# Altium schematic sheet TopLevel.SchDoc(TopLevel)
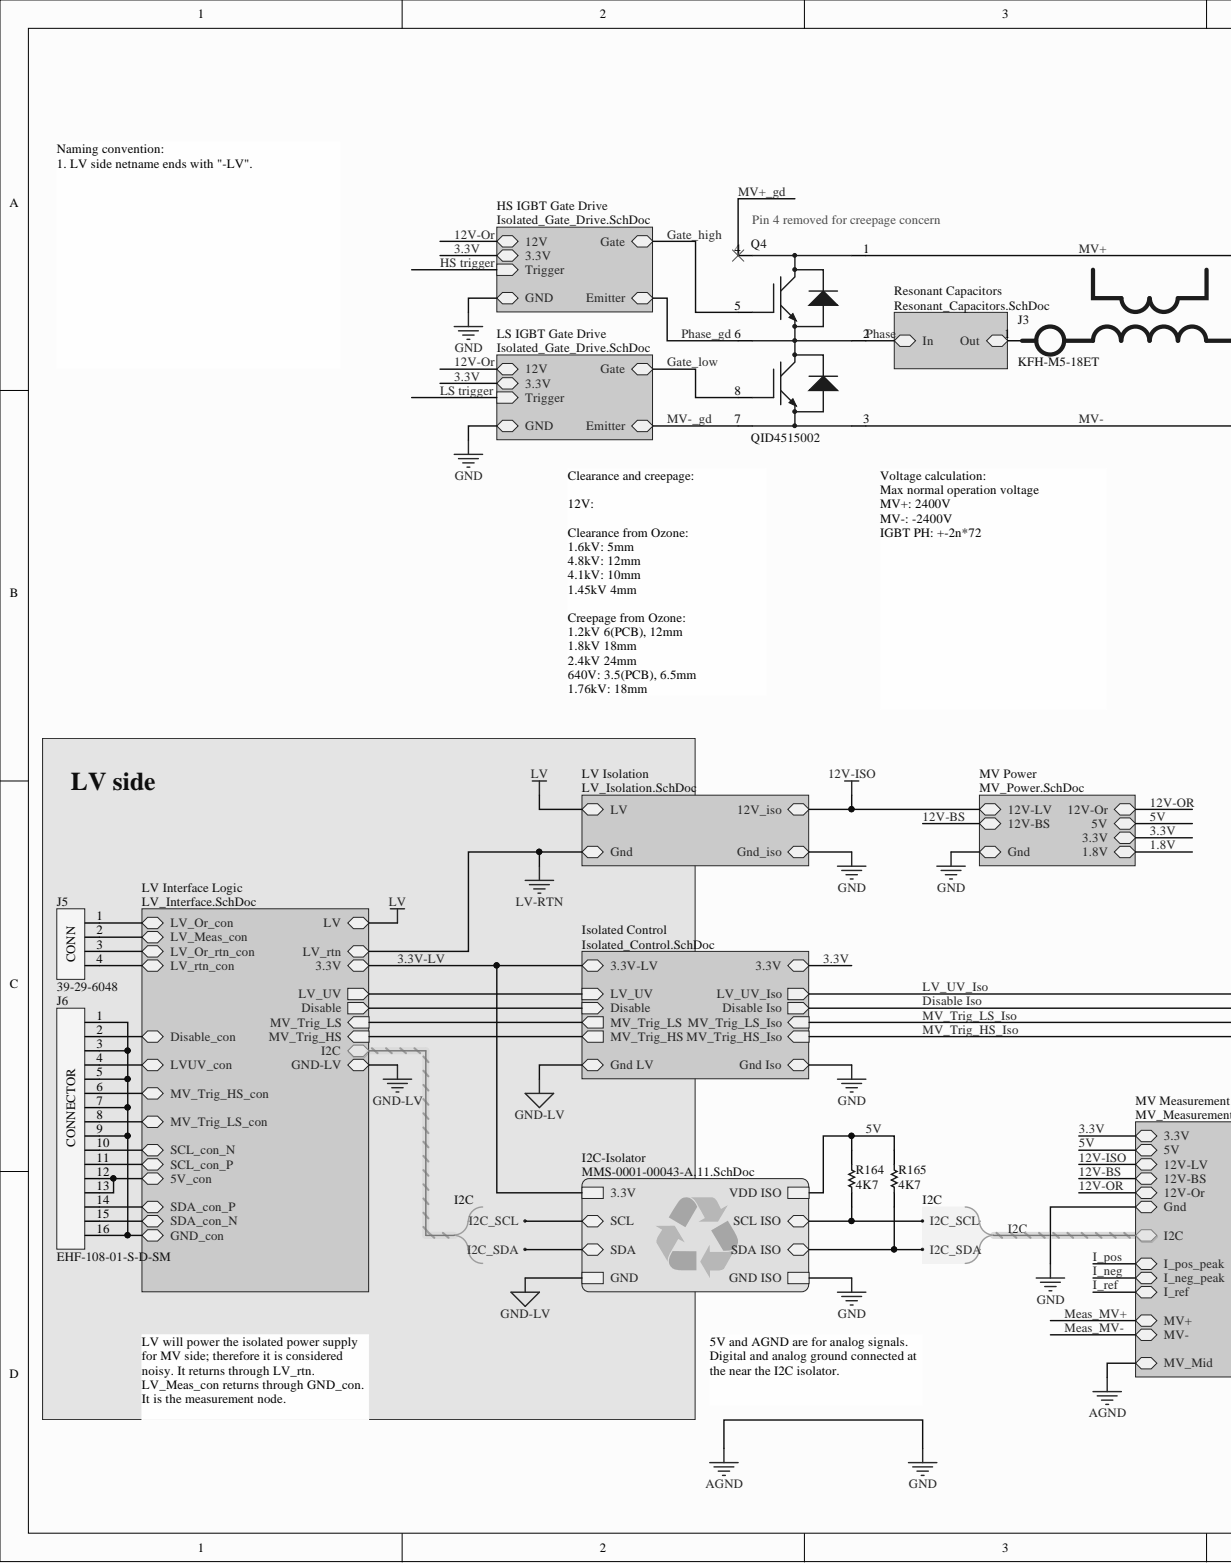
[diagram p0: TopLevel.SchDoc, left]
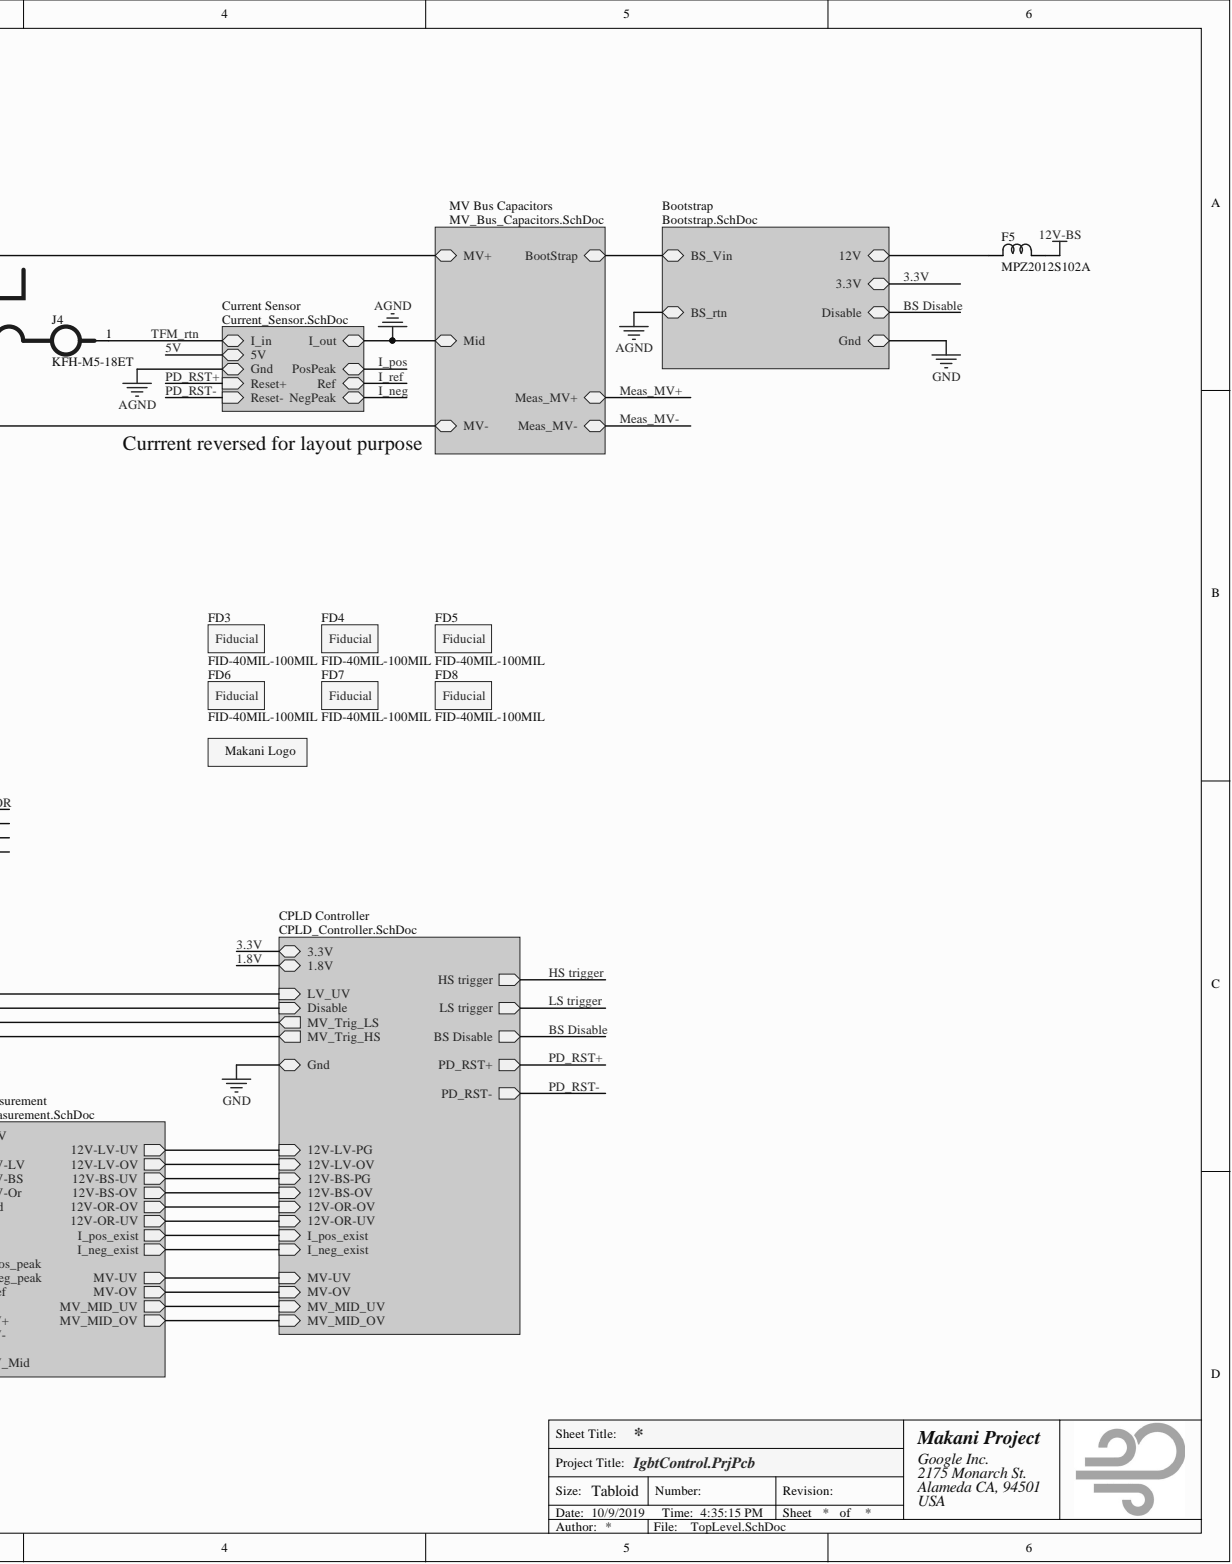
[diagram p1: TopLevel.SchDoc, right]

## Parts
F5  MPZ2012S102A  [FERRITE-0805-1P05MM]
FD3  FID-40MIL-100MIL  [FID-40MIL-100MIL]  (no BOM)
FD4  FID-40MIL-100MIL  [FID-40MIL-100MIL]  (no BOM)
FD5  FID-40MIL-100MIL  [FID-40MIL-100MIL]  (no BOM)
FD6  FID-40MIL-100MIL  [FID-40MIL-100MIL]  (no BOM)
FD7  FID-40MIL-100MIL  [FID-40MIL-100MIL]  (no BOM)
FD8  FID-40MIL-100MIL  [FID-40MIL-100MIL]  (no BOM)
J3  KFH-M5-18ET  [PENN-KFH-M5-18ET]
J4  KFH-M5-18ET  [PENN-KFH-M5-18ET]
J5  39-29-6048  [MOLX-39-29-6048 - duplicate]
J6  EHF-108-01-S-D-SM  [SAM-EHF-108-01-X-D-SM]
Logo1  Makani Logo  [MAKANI-LOGO-200MIL]  (no BOM)
Q4  QID4515002  [PWRX-QID-IGBT-HB]
R164  value 4K7  [RESC0603(1608)_N]  (hidden comment: 4K7 1% 0603(1608))
R165  value 4K7  [RESC0603(1608)_N]  (hidden comment: 4K7 1% 0603(1608))

## Nets
5V: R164.2 R165.2
12V-BS: F5.2
Gate_high: Q4.5
Gate_low: Q4.8
I2C.I2C_SCL: R164.1
I2C.I2C_SDA: R165.1
MV+: Q4.1
MV+_gd: Q4.4
MV-: Q4.3
MV-_gd: Q4.7
Phase: Q4.2
Phase_gd: Q4.6
TFM_rtn: J4.1
NetF5_1: F5.1
NetJ3_1: J3.1
NetJ5_1: J5.1
NetJ5_2: J5.2
NetJ5_3: J5.3
NetJ5_4: J5.4
NetJ6_1: J6.1 J6.3 J6.5 J6.7 J6.9 J6.16
NetJ6_2: J6.2
NetJ6_4: J6.4
NetJ6_6: J6.6
NetJ6_8: J6.8
NetJ6_10: J6.10
NetJ6_11: J6.11
NetJ6_12: J6.12 J6.13
NetJ6_14: J6.14
NetJ6_15: J6.15
1.8V:
3.3V:
3.3V-LV:
12V-ISO:
12V-Or:
AGND:
BS Disable:
Disable Iso:
GND-LV:
HS trigger:
I_neg:
I_pos:
I_ref:
LS trigger:
LV:
LV-RTN:
LV_UV_Iso:
Meas_MV+:
Meas_MV-:
MV_Trig_HS_Iso:
MV_Trig_LS_Iso:
N00432:
N00433:
N00434:
N00435:
N00436:
N00437:
N00438:
N00439:
N00440:
N00441:
N00442:
N00443:
N00444:
N00445:
N00446:
N00447:
N00448:
N00449:
N00450:
PD_RST+:
PD_RST-:

## Library data of the parts
F5 = CMP-0010-00044-3: 1000 Ohm, 1.5A, 0805 Chip Ferrite; Rated Current: 1.5; Mfg P/N: MPZ2012S102AT000; Mfg: TDK; Impedance @ 100MHz: 1000; GPN: 185-00037-00; DCR: 150; Temperature Range: -40 to 125; Supplier 1 P/N: 445-5223-1-ND; Supplier 1: DigiKey; HelpURL: http://www.tdk.co.jp/tefe02/e9413_mpz.pdf; Component Kind: Standard; Library Name: Makani Vault(migrated)
FD3-FD8 = CMP-0015-00000-1: Fiducial with 40mil copper and 100mil mask; Library Name: Makani Vault(migrated)
J3 J4 = CMP-0198-00008-4: TH 18mm studs, M5 thread; RevisionId: 1; Mfg: Penn Engineering; Folder: Components\Vendor\PENN ENG\PemNuts\; ContentType: Unified Component; AccessRights: 2147483647; Thread: M5; Supplier 1 P/N: PEMKFH-M5-18; Supplier 1: D.B. Roberts; Stud height: 18 mm; ReleaseDate: 22/03/2018 07:11:37 PM; Mfg P/N: KFH-M5-18ET; Material: Electroplated Tin; LifeCycleStateGUID: C94A9D87-DE22-4BE7-AEE4-C062168FB4CE; LifeCycle: Released; ItemHRID: CMP-0198-00008; ItemGUID: 77C44E83-7D12-4A08-AA89-24D5318BCFB5; HelpURL: http://www.pemnet.com/fastening_products/pdf/kdata.pdf; FolderGUID: 93817CE6-3017-44FA-8E59-A4AFD8205243; ContentTypeGUID: CB3C11C4-E317-11DF-B822-12313F0024A2; ComponentType: Connector; Component Kind: Standard; AncestorItemRevisionGUID: 9C205294-C155-4775-9783-1015C7892AE1; Library Name: Makani Vault(migrated)
J5 = CMP-0180-00001-5: Mini-Fit Jr, Vertical, Dual row, 4 pin; Rows: 2; Pitch: 4.2; Mfg: MOLEX; GPN: 210-00759-00; Contact Thickness: 0.38; Circuits: 4; Termination: Through Hole; Supplier 1 P/N: WM23821-ND; Supplier 1: DigiKey; Orientation: Vertical; Mfg P/N: 39-29-6048; HelpURL: http://www.molex.com/pdm_docs/sd/039281103_sd.pdf; Contact Finish: Gold; Component Kind: Standard; Library Name: Makani Vault(migrated)
J6 = CMP-0145-00031-1: 50mil 16pos Vertical SMD IDC Ejector Header; Positions: 16; Plating: 0.76um Gold; Mfg: Samtec Inc.; Locking: Yes; Supplier 1 P/N: EHF-108-01-S-D-SM; Supplier 1: Samtec Inc.; Pitch: 50mil; Orientation: Vertical; Mounting: SMD; Mfg P/N: EHF-108-01-S-D-SM; HelpURL: http://suddendocs.samtec.com/catalog_english/ehf.pdf; Component Kind: Standard; Library Name: Makani Vault(migrated)
Logo1 = CMP-0014-00000-2: Layer: Silk; Size: 200mil; Description: Makani Logo; Library Name: Makani Vault(migrated)
Q4 = CMP-0280-00000-2: PowerEx 4500V 150A IGBT Half Bridge Module; Temp Range: -40°C to +150°C; Voltage: 4500V; Mfg P/N: QID4515002; Mfg: PowerEx; HelpURL: http://www.pwrx.com/pwrx/docs/qi_4515002.pdf; Current: 150A; Component Kind: Standard; Library Name: Makani Vault(migrated)
R164 R165 = CMP-0001-00504-5: 4K7 0.1W 1% 0603 (1608 Metric)  SMD; Mfg P/N: ERJ3EKF4701V; Mfg: PANASONIC; GPN: 470-00153-00; Case-Metric: 1608; Case-EIA: 0603; Tolerance: 1%; Technology: SMT; Supplier 1 P/N: P4.70KHCT-ND; Supplier 1: DigiKey; Power: 0.1 W; Component Kind: Standard; Library Name: Makani Vault(migrated)
